# Altium schematic sheet EPC_SH.SchDoc(EPC_SH)
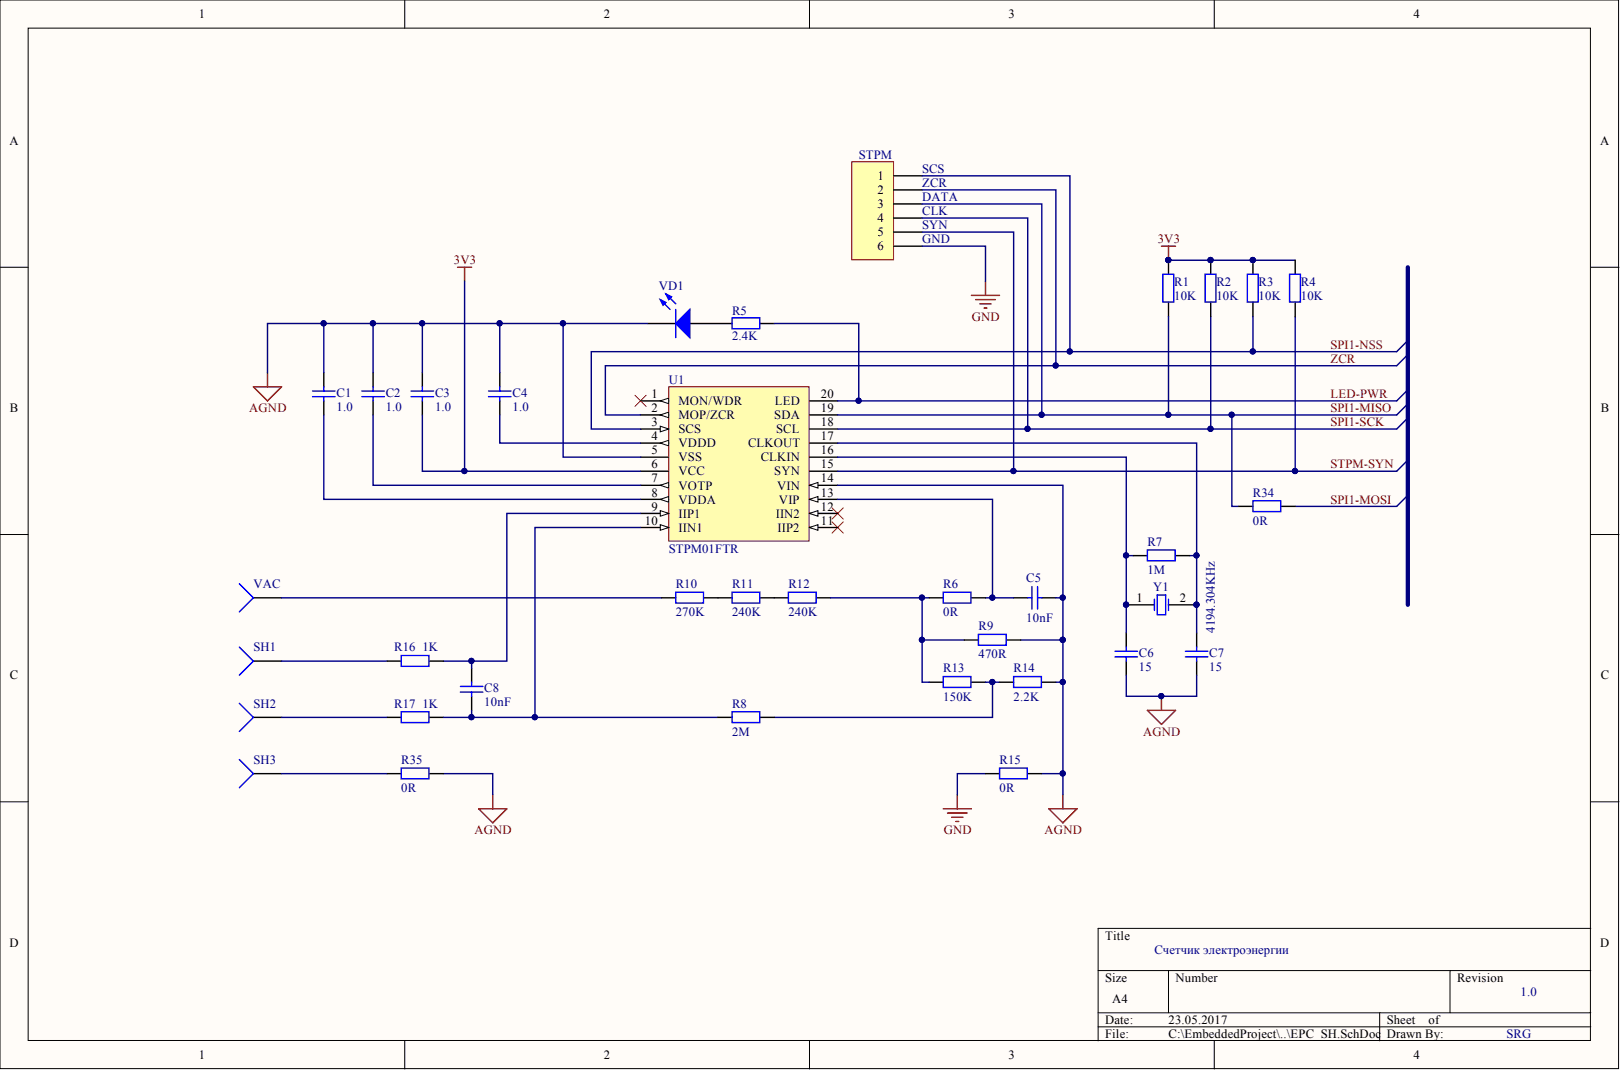
[diagram p0: EPC_SH.SchDoc]

## Parts
C1  value 1.0  [C1206]  (hidden comment: Cap)
C2  value 1.0  [C1206]  (hidden comment: Cap)
C3  value 1.0  [C1206]  (hidden comment: Cap)
C4  value 1.0  [C1206]  (hidden comment: Cap)
C5  value 10nF  [C1206]  (hidden comment: Cap)
C6  value 15  [C1206]  (hidden comment: Cap)
C7  value 15  [C1206]  (hidden comment: Cap)
C8  value 10nF  [C1206]  (hidden comment: Cap)
R1  value 10K  [R1206]  (hidden comment: Res2)
R2  value 10K  [R1206]  (hidden comment: Res2)
R3  value 10K  [R1206]  (hidden comment: Res2)
R4  value 10K  [R1206]  (hidden comment: Res2)
R5  value 2.4K  [R1206]  (hidden comment: Res2)
R6  value 0R  [R1206]  (hidden comment: Res2)
R7  value 1M  [R1206]  (hidden comment: Res2)
R8  value 2M  [R1206]  (hidden comment: Res2)
R9  value 470R  [R1206]  (hidden comment: Res2)
R10  value 270K  [R1206]  (hidden comment: Res2)
R11  value 240K  [R1206]  (hidden comment: Res2)
R12  value 240K  [R1206]  (hidden comment: Res2)
R13  value 150K  [R1206]  (hidden comment: Res2)
R14  value 2.2K  [R1206]  (hidden comment: Res2)
R15  value 0R  [R1206]  (hidden comment: Res2)
R16  value 1K  [R1206]  (hidden comment: Res2)
R17  value 1K  [R1206]  (hidden comment: Res2)
R34  value 0R  [R1206]  (hidden comment: Res2)
R35  value 0R  [R1206]  (hidden comment: Res2)
SH1  Socket  [PIN1-PWR]  (value not drawn)
SH2  Socket  [PIN1-PWR]  (value not drawn)
SH3  Socket  [PIN1-PWR]  (value not drawn)
STPM  Header 6  [HDR1X6]  (value not drawn)
U1  STPM01  value STPM01FTR  PartNumber: STPM01FTR  [SSOP-20W2]
VAC  Socket  [PIN1-PWR]  (value not drawn)
VD1  Energy  [LED1206]  (value not drawn)
Y1  value 4194.304KHz  [HC49/4H_SMX]  (hidden comment: XTAL)

## Nets
3V3: C3.1 R1.2 R2.2 R3.2 R4.2 U1.6(VCC)
AGND: C1.2 C2.2 C3.2 C4.2 C5.1 C6.2 C7.2 R9.1 R14.1 R15.1 R35.1 U1.5(VSS) U1.14(VIN) VD1.2
GND: R15.2 STPM.6
LED-PWR: R5.2 U1.20(LED)
SPI1-MISO: R1.1 R34.2 STPM.3 U1.19(SDA)
SPI1-MOSI: R34.1
SPI1-NSS: R3.1 STPM.1 U1.3(SCS)
SPI1-SCK: R2.1 STPM.4 U1.18(SCL)
STPM-SYN: R4.1 STPM.5 U1.15(SYN)
ZCR: STPM.2 U1.2(MOP/ZCR)
NetC1_1: C1.1 U1.8(VDDA)
NetC2_1: C2.1 U1.7(VOTP)
NetC4_1: C4.1 U1.4(VDDD)
NetC5_2: C5.2 R6.1 U1.13(VIP)
NetC6_1: C6.1 R7.2 U1.16(CLKIN) Y1.1
NetC7_1: C7.1 R7.1 U1.17(CLKOUT) Y1.2
NetC8_1: C8.1 R8.2 R17.1 U1.10(IIN1)
NetC8_2: C8.2 R16.1 U1.9(IIP1)
NetR5_1: R5.1 VD1.1
NetR6_2: R6.2 R9.2 R12.1 R13.2
NetR8_1: R8.1 R13.1 R14.2
NetR10_1: R10.1 R11.2
NetR10_2: R10.2 VAC.1
NetR11_1: R11.1 R12.2
NetR16_2: R16.2 SH1.1
NetR17_2: R17.2 SH2.1
NetR35_2: R35.2 SH3.1
N00064:

Not connected: U1 1(MON/WDR) 11(IIP2) 12(IIN2)

## Library data of the parts
C1-C4 = Cap: Capacitor; PartNumber: GRM31MR71H105K; DeliveryTime: 7; Component Kind: Standard; Library Name: Miscellaneous Devices.IntLib; Price: 5; Signal Integrity: Cap; Simulation: CAP
C5 C8 = Cap: Capacitor; PartNumber: GRM31BR72J103K; DeliveryTime: 7; Component Kind: Standard; Library Name: Miscellaneous Devices.IntLib; Price: 5.0; Signal Integrity: Cap; Simulation: CAP
C6 C7 = Cap: Capacitor; PartNumber: GRM3165C1H150J; DeliveryTime: 7; Component Kind: Standard; Library Name: Miscellaneous Devices.IntLib; Price: 5.0; Signal Integrity: Cap; Simulation: CAP
R1-R4 = Res2: Resistor; PartNumber: RC1206JK-0710KL; DeliveryTime: 7; Component Kind: Standard; Library Name: Miscellaneous Devices.IntLib; Price: 0.90; Signal Integrity: Res; Simulation: RESISTOR
R5 = Res2: Resistor; PartNumber: RC1206JK-072K4L; DeliveryTime: 7; Component Kind: Standard; Library Name: Miscellaneous Devices.IntLib; Price: 0.90; Signal Integrity: Res; Simulation: RESISTOR
R6 R15 R34 R35 = Res2: Resistor; PartNumber: RC1206FK-070RL; DeliveryTime: 7; Component Kind: Standard; Library Name: Miscellaneous Devices.IntLib; Price: 0.90; Signal Integrity: Res; Simulation: RESISTOR
R7 = Res2: Resistor; PartNumber: RC1206FK-071ML; DeliveryTime: 7; Component Kind: Standard; Library Name: Miscellaneous Devices.IntLib; Price: 0.90; Signal Integrity: Res; Simulation: RESISTOR
R8 = Res2: Resistor; PartNumber: RC1206FK-072ML; DeliveryTime: 7; Component Kind: Standard; Library Name: Miscellaneous Devices.IntLib; Price: 0.90; Signal Integrity: Res; Simulation: RESISTOR
R9 = Res2: Resistor; PartNumber: RC1206FK-07470RL; DeliveryTime: 7; Component Kind: Standard; Library Name: Miscellaneous Devices.IntLib; Price: 0.90; Signal Integrity: Res; Simulation: RESISTOR
R10 = Res2: Resistor; PartNumber: RC1206FK-07270KL; DeliveryTime: 7; Component Kind: Standard; Library Name: Miscellaneous Devices.IntLib; Price: 0.90; Signal Integrity: Res; Simulation: RESISTOR
R11 R12 = Res2: Resistor; PartNumber: RC1206FK-07240KL; DeliveryTime: 7; Component Kind: Standard; Library Name: Miscellaneous Devices.IntLib; Price: 0.90; Signal Integrity: Res; Simulation: RESISTOR
R13 = Res2: Resistor; PartNumber: RC1206FK-07150KL; DeliveryTime: 7; Component Kind: Standard; Library Name: Miscellaneous Devices.IntLib; Price: 0.90; Signal Integrity: Res; Simulation: RESISTOR
R14 = Res2: Resistor; PartNumber: RC1206FK-072K2L; DeliveryTime: 7; Component Kind: Standard; Library Name: Miscellaneous Devices.IntLib; Price: 0.90; Signal Integrity: Res; Simulation: RESISTOR
R16 R17 = Res2: Resistor; PartNumber: RC1206FK-071KL; DeliveryTime: 7; Component Kind: Standard; Library Name: Miscellaneous Devices.IntLib; Price: 0.90; Signal Integrity: Res; Simulation: RESISTOR
STPM = Header 6: Header, 6-Pin; Component Kind: Standard; Library Name: Miscellaneous Connectors.IntLib; Signal Integrity: Connector
U1 = STPM01: Single-phase energy metering; DeliveryTime: 28; Component Kind: Standard; Library Name: Schlib1.SchLib; Price: 211.00
VD1 = LED0: SMD LED; PartNumber: BL-LS1206UHR; DeliveryTime: 7; value: RED; Component Kind: Standard; Library Name: Miscellaneous Devices.IntLib; Price: 9.00; Simulation: LED0
Y1 = XTAL: Crystal Oscillator; PartNumber: AS-4.194304-18-SMD; DeliveryTime: 21; Value: 4194.304KHz; Component Kind: Standard; Library Name: Miscellaneous Devices.IntLib; Price: 32.00; Simulation: XTAL
SH1-SH3 VAC = Socket: Component Kind: Standard; Description: Socket; LatestRevisionDate: 17-Jul-2002; LatestRevisionNote: Re-released for DXP Platform.; Library Name: Miscellaneous Connectors.IntLib; Signal Integrity: Connector; Publisher: Altium Limited
